# Altium schematic sheet [05] - PMOD HEADERS.SchDoc("[05] - PMOD HEADERS")
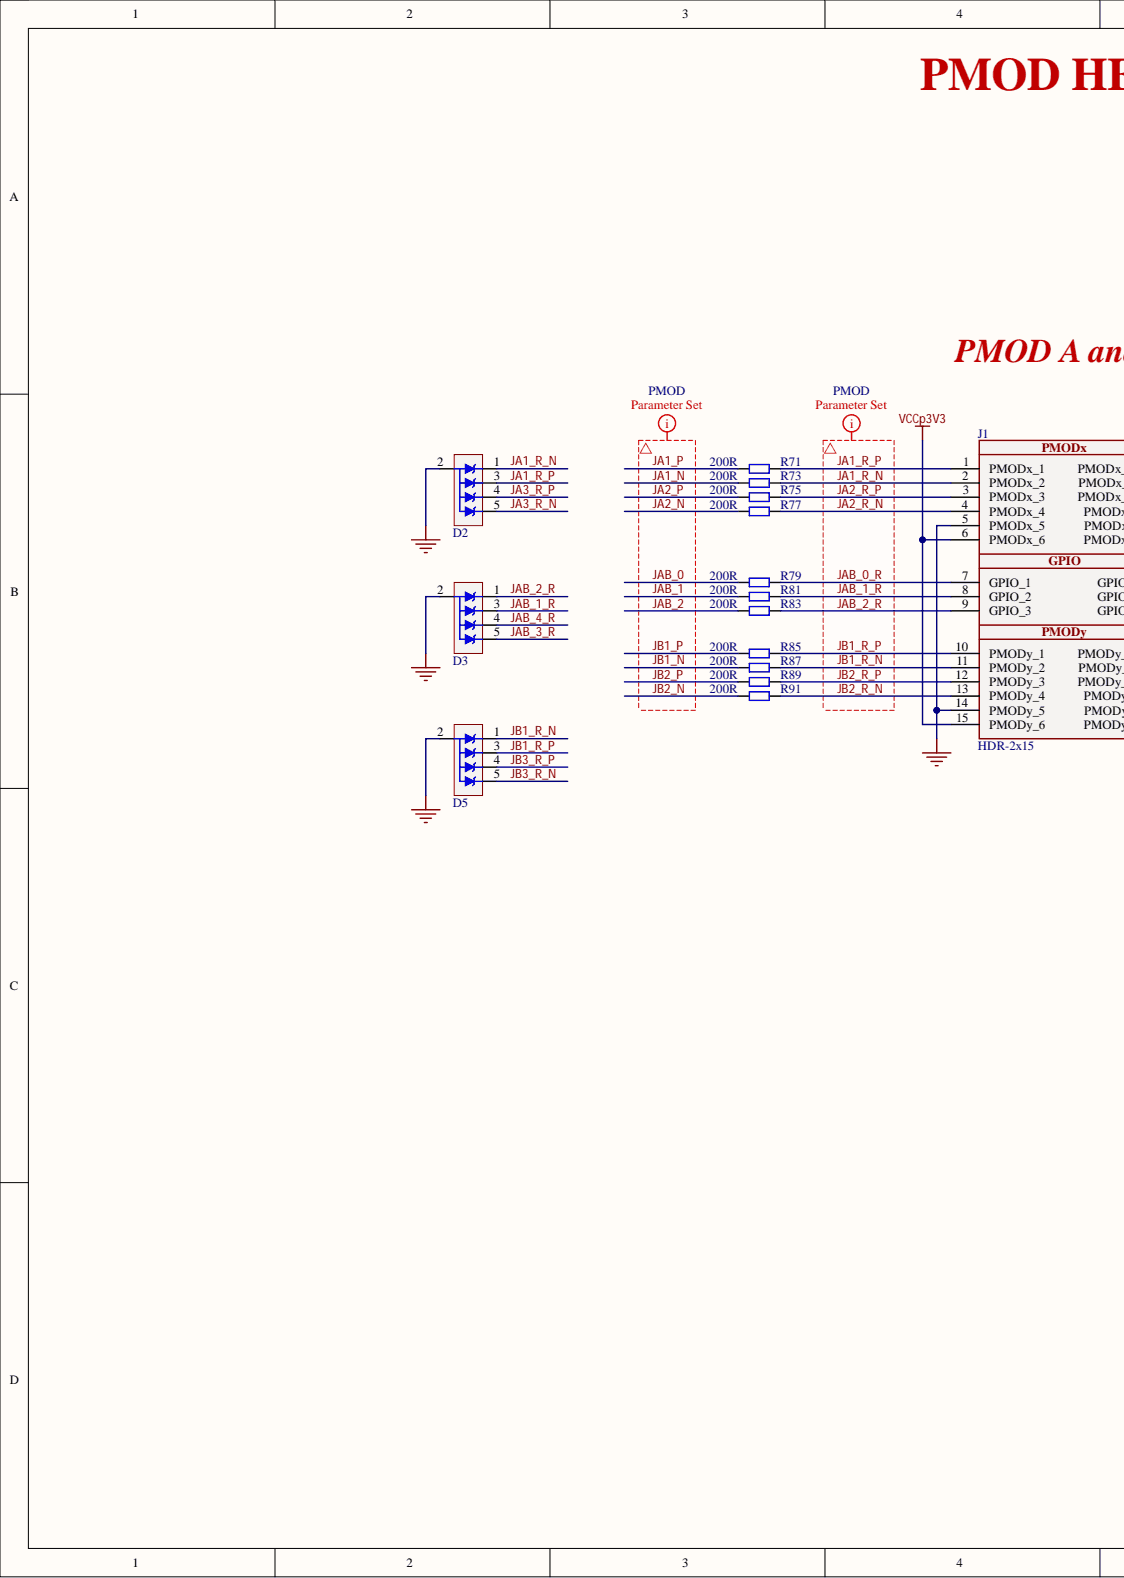
[diagram p0: [05] - PMOD HEADERS.SchDoc, left]
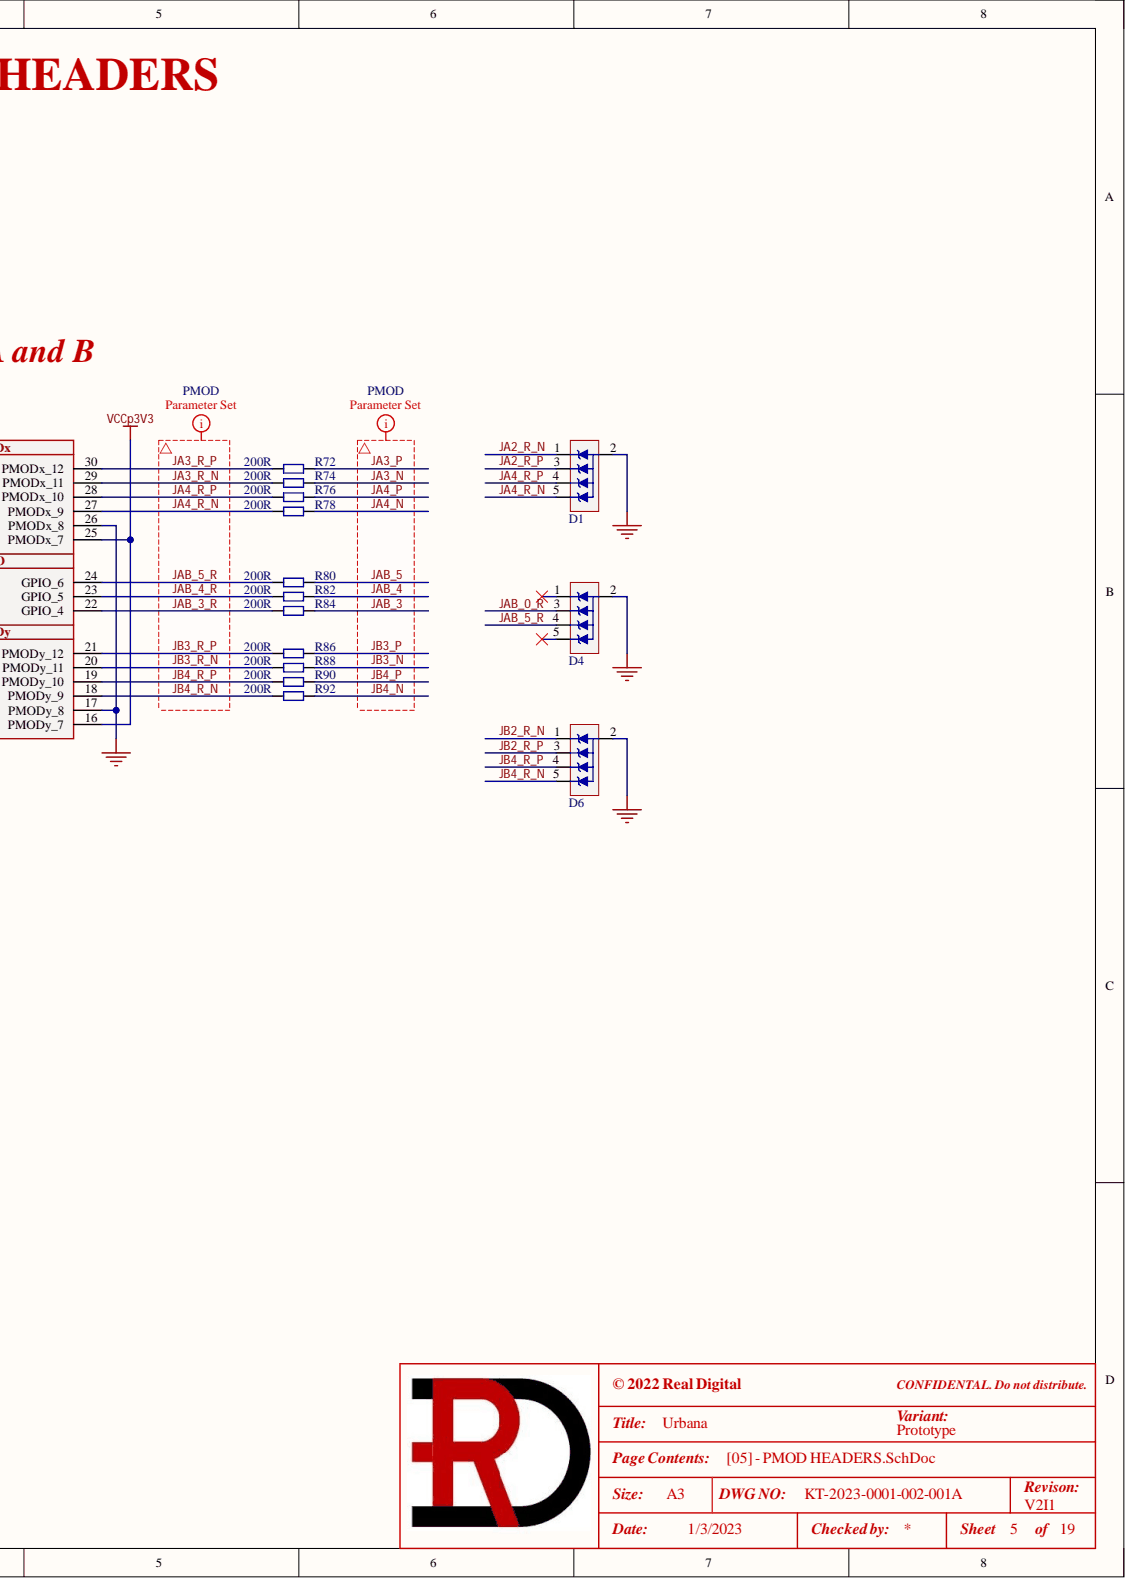
[diagram p1: [05] - PMOD HEADERS.SchDoc, right]

## Parts
D1  NSQA6V8AW5T2G  [SOT-323-5]  (value not drawn)
D2  NSQA6V8AW5T2G  [SOT-323-5]  (value not drawn)
D3  NSQA6V8AW5T2G  [SOT-323-5]  (value not drawn)
D4  NSQA6V8AW5T2G  [SOT-323-5]  (value not drawn)
D5  NSQA6V8AW5T2G  [SOT-323-5]  (value not drawn)
D6  NSQA6V8AW5T2G  [SOT-323-5]  (value not drawn)
J1  HDR-2x15  [PMOD_X2_PLUS_6_V2]
R71  200R  [R0402]
R72  200R  [R0402]
R73  200R  [R0402]
R74  200R  [R0402]
R75  200R  [R0402]
R76  200R  [R0402]
R77  200R  [R0402]
R78  200R  [R0402]
R79  200R  [R0402]
R80  200R  [R0402]
R81  200R  [R0402]
R82  200R  [R0402]
R83  200R  [R0402]
R84  200R  [R0402]
R85  200R  [R0402]
R86  200R  [R0402]
R87  200R  [R0402]
R88  200R  [R0402]
R89  200R  [R0402]
R90  200R  [R0402]
R91  200R  [R0402]
R92  200R  [R0402]

## Nets
GND: D1.2 D2.2 D3.2 D4.2 D5.2 D6.2 J1.5(PMODx_5) J1.14(PMODy_5) J1.17(PMODy_8) J1.26(PMODx_8)
VCCp3V3: J1.6(PMODx_6) J1.15(PMODy_6) J1.16(PMODy_7) J1.25(PMODx_7)
JA1_N: R73.1
JA1_P: R71.1
JA1_R_N: D2.1 J1.2(PMODx_2) R73.2
JA1_R_P: D2.3 J1.1(PMODx_1) R71.2
JA2_N: R77.1
JA2_P: R75.1
JA2_R_N: D1.1 J1.4(PMODx_4) R77.2
JA2_R_P: D1.3 J1.3(PMODx_3) R75.2
JA3_N: R74.2
JA3_P: R72.2
JA3_R_N: D2.5 J1.29(PMODx_11) R74.1
JA3_R_P: D2.4 J1.30(PMODx_12) R72.1
JA4_N: R78.2
JA4_P: R76.2
JA4_R_N: D1.5 J1.27(PMODx_9) R78.1
JA4_R_P: D1.4 J1.28(PMODx_10) R76.1
JAB_0: R79.1
JAB_0_R: D4.3 J1.7(GPIO_1) R79.2
JAB_1: R81.1
JAB_1_R: D3.3 J1.8(GPIO_2) R81.2
JAB_2: R83.1
JAB_2_R: D3.1 J1.9(GPIO_3) R83.2
JAB_3: R84.2
JAB_3_R: D3.5 J1.22(GPIO_4) R84.1
JAB_4: R82.2
JAB_4_R: D3.4 J1.23(GPIO_5) R82.1
JAB_5: R80.2
JAB_5_R: D4.4 J1.24(GPIO_6) R80.1
JB1_N: R87.1
JB1_P: R85.1
JB1_R_N: D5.1 J1.11(PMODy_2) R87.2
JB1_R_P: D5.3 J1.10(PMODy_1) R85.2
JB2_N: R91.1
JB2_P: R89.1
JB2_R_N: D6.1 J1.13(PMODy_4) R91.2
JB2_R_P: D6.3 J1.12(PMODy_3) R89.2
JB3_N: R88.2
JB3_P: R86.2
JB3_R_N: D5.5 J1.20(PMODy_11) R88.1
JB3_R_P: D5.4 J1.21(PMODy_12) R86.1
JB4_N: R92.2
JB4_P: R90.2
JB4_R_N: D6.5 J1.18(PMODy_9) R92.1
JB4_R_P: D6.4 J1.19(PMODy_10) R90.1

Not connected: D4 1 5

## Library data of the parts
D1-D6 = NSQA6V8: TVS DIODE 5V 13V SC88A; Manufacturer 1: ON Semiconductor; .Checked_PCB: AC; .Creator: RV; C P/N: 121-0019; LoadStatus: Load; Category: Diodes; Component Kind: Standard; Library Name: KT-RealDigital.IntLib; Manufacturer 1 Part Number: NSQA6V8AW5T2G; .Checked_SCH: AC
J1 = PMODx2_Plus_6: Header 2.54mm, 2x15; Manufacturer 1: Morlinkom; Manufacturer 1 Part Number: 230-30; .Checked_PCB: RV; C P/N: 163-0392; LoadStatus: Load; Category: Connectors; Component Kind: Standard; Library Name: KT-RealDigital.IntLib; .Checked_SCH: RV; .Creator: RV
R71-R92 = RC0402JR-07200RL: RES SMD 200 OHM 5% 1/16W 0402; Manufacturer 1: Yageo; .Checked_PCB: AC; .Creator: RV; C P/N: 101-0045; LoadStatus: Load; Category: Resistors; Component Kind: Standard; Library Name: KT-RealDigital.IntLib; Manufacturer 1 Part Number: RC0402JR-07200RL; Tolerance: 5%; .Checked_SCH: AC
